# Altium schematic sheet ГРЛМ.468333.001 Э3.SchDoc
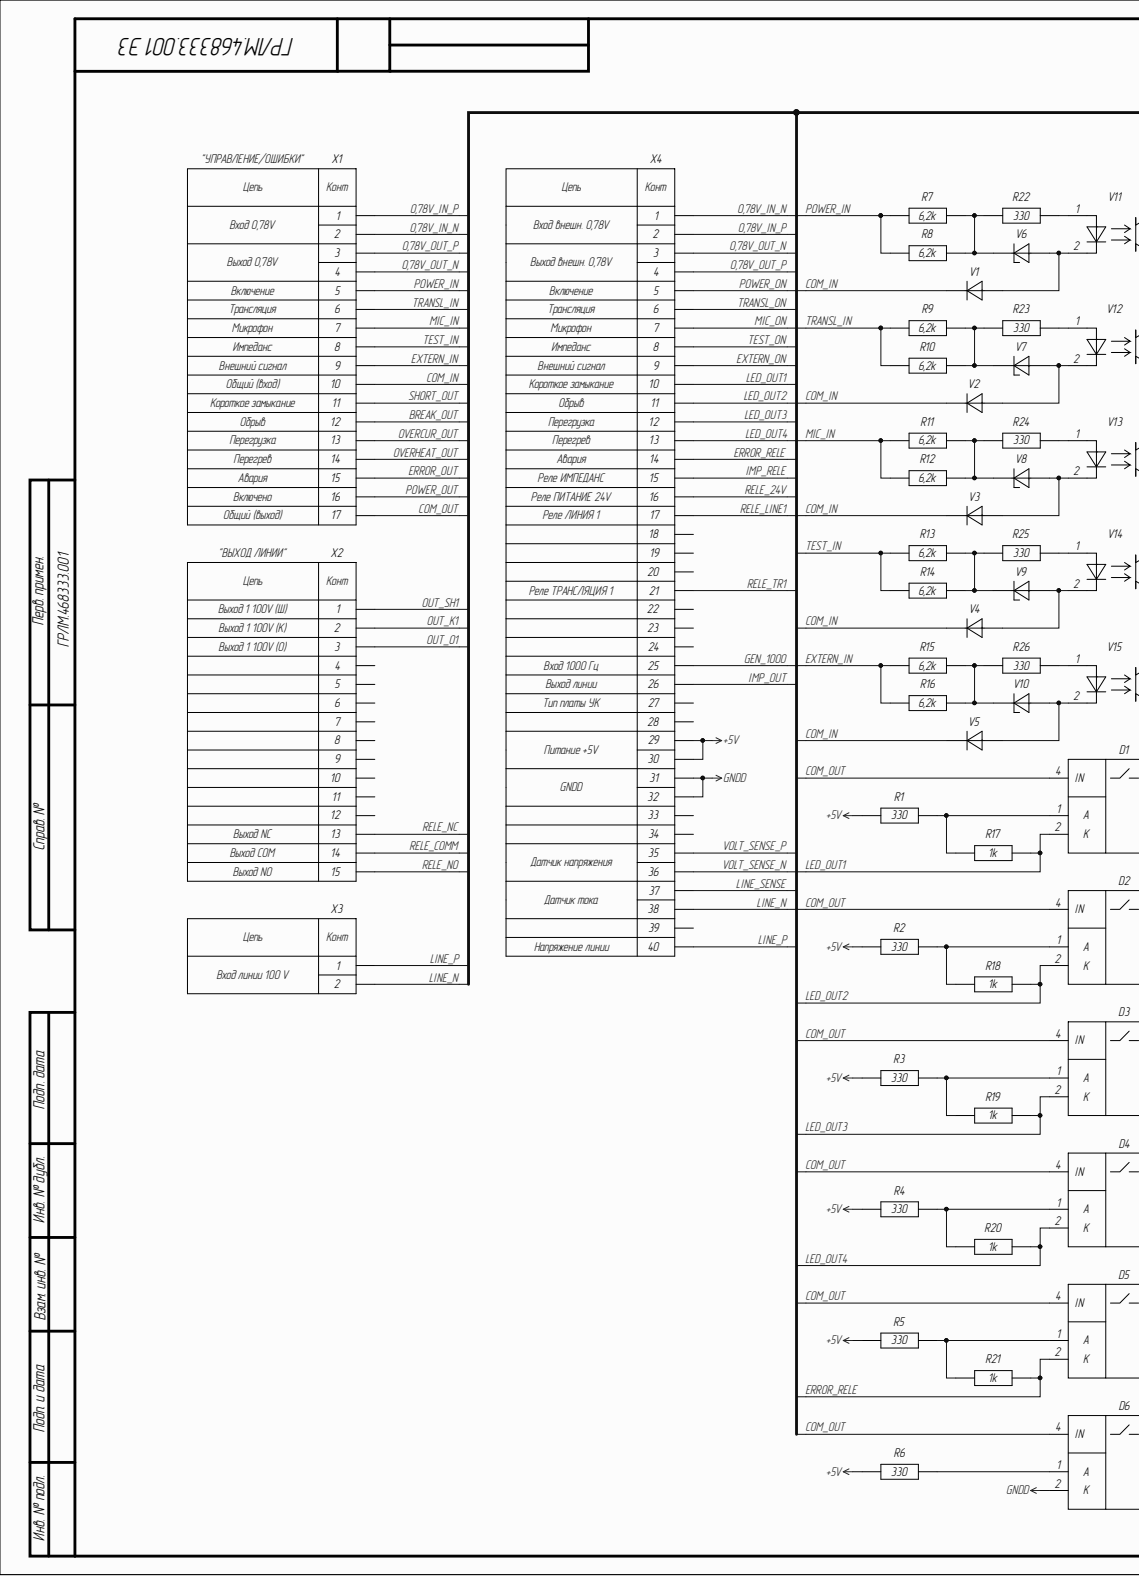
[diagram p0: ГРЛМ.468333.001 Э3.SchDoc, left]
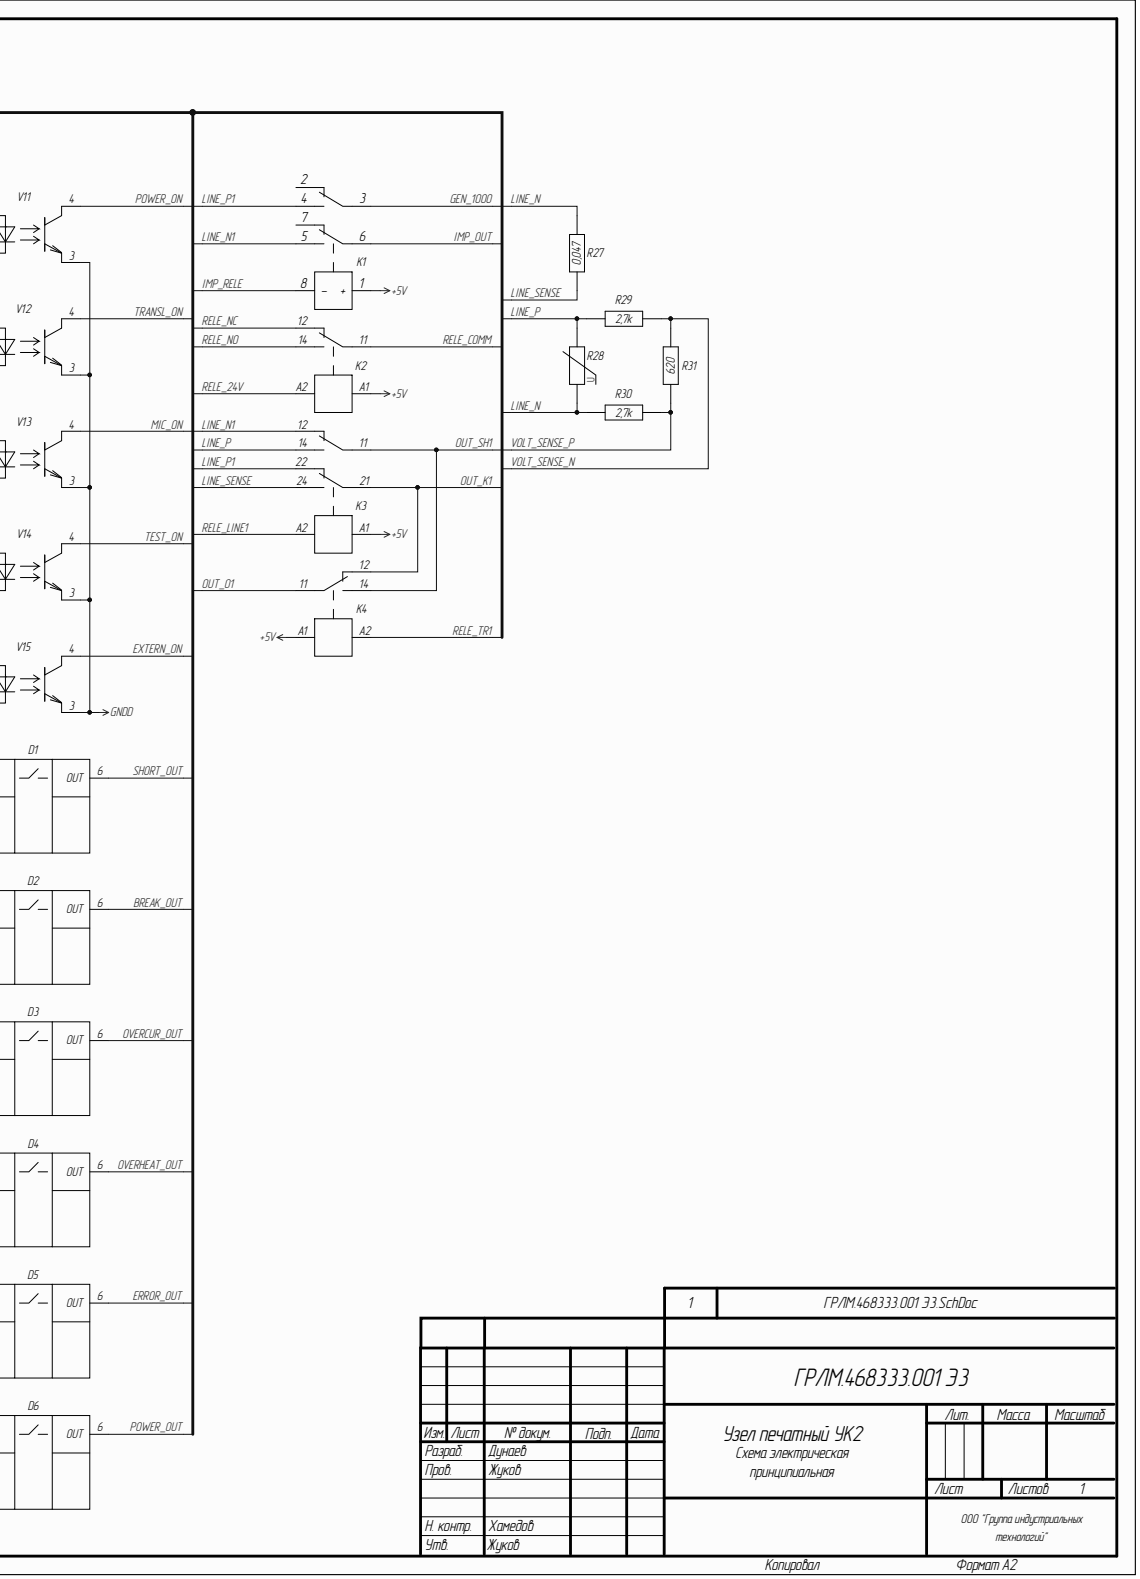
[diagram p1: ГРЛМ.468333.001 Э3.SchDoc, right]

## Parts
D1  LH1510AAB  [LH1510AAB]  (value not drawn)
D2  LH1510AAB  [LH1510AAB]  (value not drawn)
D3  LH1510AAB  [LH1510AAB]  (value not drawn)
D4  LH1510AAB  [LH1510AAB]  (value not drawn)
D5  LH1510AAB  [LH1510AAB]  (value not drawn)
D6  LH1510AAB  [LH1510AAB]  (value not drawn)
K1  IM03GR 1-1462037-4  [IM03GR]  (value not drawn)
K2  RY211005  [RY211005]  (value not drawn)
K3  RT424005  [RT424005]  (value not drawn)
K4  RY211005  [RY211005]  (value not drawn)
R1  value 330  [RES0805]  (hidden comment: RES_0805)
R2  value 330  [RES0805]  (hidden comment: RES_0805)
R3  value 330  [RES0805]  (hidden comment: RES_0805)
R4  value 330  [RES0805]  (hidden comment: RES_0805)
R5  value 330  [RES0805]  (hidden comment: RES_0805)
R6  value 330  [RES0805]  (hidden comment: RES_0805)
R7  value 6,2k  [RES2512]  (hidden comment: RES_2512)
R8  value 6,2k  [RES2512]  (hidden comment: RES_2512)
R9  value 6,2k  [RES2512]  (hidden comment: RES_2512)
R10  value 6,2k  [RES2512]  (hidden comment: RES_2512)
R11  value 6,2k  [RES2512]  (hidden comment: RES_2512)
R12  value 6,2k  [RES2512]  (hidden comment: RES_2512)
R13  value 6,2k  [RES2512]  (hidden comment: RES_2512)
R14  value 6,2k  [RES2512]  (hidden comment: RES_2512)
R15  value 6,2k  [RES2512]  (hidden comment: RES_2512)
R16  value 6,2k  [RES2512]  (hidden comment: RES_2512)
R17  value 1k  [RES0805]  (hidden comment: RES_0805)
R18  value 1k  [RES0805]  (hidden comment: RES_0805)
R19  value 1k  [RES0805]  (hidden comment: RES_0805)
R20  value 1k  [RES0805]  (hidden comment: RES_0805)
R21  value 1k  [RES0805]  (hidden comment: RES_0805)
R22  value 330  [RES0805]  (hidden comment: RES_0805)
R23  value 330  [RES0805]  (hidden comment: RES_0805)
R24  value 330  [RES0805]  (hidden comment: RES_0805)
R25  value 330  [RES0805]  (hidden comment: RES_0805)
R26  value 330  [RES0805]  (hidden comment: RES_0805)
R27  value 0,047  [RES2512]  (hidden comment: RES_2512)
R28  JVR-14N201K  [JVR-14N201K]  (value not drawn)
R29  value 2,7k  [RES1210]  (hidden comment: RES_1210)
R30  value 2,7k  [RES1210]  (hidden comment: RES_1210)
R31  value 620  [RES1210]  (hidden comment: RES_1210)
V1  LL4148  [LL4148]  (value not drawn)
V2  LL4148  [LL4148]  (value not drawn)
V3  LL4148  [LL4148]  (value not drawn)
V4  LL4148  [LL4148]  (value not drawn)
V5  LL4148  [LL4148]  (value not drawn)
V6  BZV55C4V7  [BZV55]  (value not drawn)
V7  BZV55C4V7  [BZV55]  (value not drawn)
V8  BZV55C4V7  [BZV55]  (value not drawn)
V9  BZV55C4V7  [BZV55]  (value not drawn)
V10  BZV55C4V7  [BZV55]  (value not drawn)
V11  HCPL-181-06AE  [HCPL-181]  (value not drawn)
V12  HCPL-181-06AE  [HCPL-181]  (value not drawn)
V13  HCPL-181-06AE  [HCPL-181]  (value not drawn)
V14  HCPL-181-06AE  [HCPL-181]  (value not drawn)
V15  HCPL-181-06AE  [HCPL-181]  (value not drawn)
X1  15EDGRC-3.81-17P-14  (value not drawn)
X2  2EDGRC-5.08-15P-14  (value not drawn)
X3  PWL2-02 (B2P-VH-B)  [PWL2-02]  (value not drawn)
X4  FDC-40  (value not drawn)

## Nets
+5V: K1.1(+) K2.A1 K3.A1 K4.A1 R1.1 R2.1 R3.1 R4.1 R5.1 R6.1 X4.29 X4.30
GNDD: D6.2(K) V11.3 V12.3 V13.3 V14.3 V15.3 X4.31 X4.32
0,78V_IN_N: X1.2 X4.1
0,78V_IN_P: X1.1 X4.2
0,78V_OUT_N: X1.4 X4.3
0,78V_OUT_P: X1.3 X4.4
BREAK_OUT: D2.6(OUT) X1.12
COM_IN: V1.1 V2.1 V3.1 V4.1 V5.1 X1.10
COM_OUT: D1.4(IN) D2.4(IN) D3.4(IN) D4.4(IN) D5.4(IN) D6.4(IN) X1.17
ERROR_OUT: D5.6(OUT) X1.15
ERROR_RELE: D5.2(K) R21.2 X4.14
EXTERN_IN: R15.1 R16.1 X1.9
EXTERN_ON: V15.4 X4.9
GEN_1000: K1.3 X4.25
IMP_OUT: K1.6 X4.26
IMP_RELE: K1.8(-) X4.15
LED_OUT1: D1.2(K) R17.2 X4.10
LED_OUT2: D2.2(K) R18.2 X4.11
LED_OUT3: D3.2(K) R19.2 X4.12
LED_OUT4: D4.2(K) R20.2 X4.13
LINE_N: R27.1 R28.1 R30.1 X3.2 X4.38
LINE_N1: K1.5 K3.12
LINE_P: K3.14 R28.2 R29.1 X3.1 X4.40
LINE_P1: K1.4 K3.22
LINE_SENSE: K3.24 R27.2 X4.37
MIC_IN: R11.1 R12.1 X1.7
MIC_ON: V13.4 X4.7
OUT_K1: K3.21 K4.12 X2.2
OUT_O1: K4.11 X2.3
OUT_SH1: K3.11 K4.14 X2.1
OVERCUR_OUT: D3.6(OUT) X1.13
OVERHEAT_OUT: D4.6(OUT) X1.14
POWER_IN: R7.1 R8.1 X1.5
POWER_ON: V11.4 X4.5
POWER_OUT: D6.6(OUT) X1.16
RELE_24V: K2.A2 X4.16
RELE_COMM: K2.11 X2.14
RELE_LINE1: K3.A2 X4.17
RELE_NC: K2.12 X2.13
RELE_NO: K2.14 X2.15
RELE_TR1: K4.A2 X4.21
SHORT_OUT: D1.6(OUT) X1.11
TEST_IN: R13.1 R14.1 X1.8
TEST_ON: V14.4 X4.8
TRANSL_IN: R9.1 R10.1 X1.6
TRANSL_ON: V12.4 X4.6
VOLT_SENSE_N: R29.2 R31.2 X4.36
VOLT_SENSE_P: R30.2 R31.1 X4.35
NetD1_1: D1.1(A) R1.2 R17.1
NetD2_1: D2.1(A) R2.2 R18.1
NetD3_1: D3.1(A) R3.2 R19.1
NetD4_1: D4.1(A) R4.2 R20.1
NetD5_1: D5.1(A) R5.2 R21.1
NetD6_1: D6.1(A) R6.2
NetR7_2: R7.2 R8.2 R22.1 V6.1
NetR9_2: R9.2 R10.2 R23.1 V7.1
NetR11_2: R11.2 R12.2 R24.1 V8.1
NetR13_2: R13.2 R14.2 R25.1 V9.1
NetR15_2: R15.2 R16.2 R26.1 V10.1
NetR22_2: R22.2 V11.1
NetR23_2: R23.2 V12.1
NetR24_2: R24.2 V13.1
NetR25_2: R25.2 V14.1
NetR26_2: R26.2 V15.1
NetV1_2: V1.2 V6.2 V11.2
NetV2_2: V2.2 V7.2 V12.2
NetV3_2: V3.2 V8.2 V13.2
NetV4_2: V4.2 V9.2 V14.2
NetV5_2: V5.2 V10.2 V15.2
N00028:

Not connected: K1 2 7; X2 4 5 6 7 8 9 10 11 12; X4 18 19 20 22 23 24 27 28 33 34 39

## Library data of the parts
D1-D6 = LH1510AAB: Оптореле; Производитель: Vishay; Component Kind: Standard; Library Name: Микросхемы.SchLib
K1 = IM03GR 1-1462037-4: Реле DC 5V; Производитель: TE Connectivity; Component Kind: Standard; Library Name: Реле.SchLib
K2 K4 = RY211005: Реле DC 5V 8A; Производитель: TE Connectivity; Component Kind: Standard; Library Name: Реле.SchLib
K3 = RT424005: Реле DC 5V 8A; Производитель: TE Connectivity; Component Kind: Standard; Library Name: Реле.SchLib
R1-R6 = RES_0805: Резистор SMD; Артикул: 0805-3,3 кОм±5%; Производитель: YAGEO; Component Kind: Standard; Type: RC0805JR-073K3L; Library Name: Резисторы.SchLib
R7-R16 = RES_2512: Резистор SMD; Артикул: 2512-6,2 кОм±5%; Производитель: YAGEO; Component Kind: Standard; Type: RC2512JK-076K2L; Library Name: Резисторы.SchLib
R17-R21 = RES_0805: Резистор SMD; Артикул: 0805-1 кОм±5%; Производитель: YAGEO; Component Kind: Standard; Type: RC0805JR-071KL; Library Name: Резисторы.SchLib
R22-R26 = RES_0805: Резистор SMD; Артикул: 0805-330 Ом±5%; Производитель: YAGEO; Component Kind: Standard; Type: RC0805JR-07330RL; Library Name: Резисторы.SchLib
R27 = RES_2512: Резистор SMD; Артикул: 2512-0,047 Ом±1%; Производитель: Tyco; Component Kind: Standard; Type: TLM3ADR047FTE; Library Name: Резисторы.SchLib
R28 = JVR-14N201K: Варистор 130 В 57 Дж; Производитель: Joyin; Component Kind: Standard; Library Name: Резисторы.SchLib
R29 R30 = RES_1210: Резистор SMD; Артикул: 1210-2,7 кОм±1%; Производитель: YAGEO; Component Kind: Standard; Type: RC1210FR-072K7L; Library Name: Резисторы.SchLib
R31 = RES_1210: Резистор SMD; Артикул: 1210-620 Ом±1%; Производитель: YAGEO; Component Kind: Standard; Type: RC1210FR-07620RL; Library Name: Резисторы.SchLib
V1-V5 = LL4148: Диод выпрямительный; Производитель: Vishay; Component Kind: Standard; Library Name: ГРЛМ.468363.001 Э3.SCHLIB
V6-V10 = BZV55C4V7: Стабилитрон; Производитель: NXP; Component Kind: Standard; Library Name: Полупроводники.SchLib
V11-V15 = HCPL-181-06AE: Оптопара; Производитель: Broadcom; Component Kind: Standard; Library Name: Микросхемы.SchLib
X1 = 15EDGRC-3.81-17P-14: Вилка; Component Kind: Standard; Library Name: Соединители.SchLib
X2 = 2EDGRC-5.08-15P-14: Вилка; Component Kind: Standard; Library Name: Соединители.SchLib
X3 = PWL2-02 (B2P-VH-B): Вилка; Component Kind: Standard; Library Name: Соединители.SchLib
X4 = FDC-40: Вилка; Component Kind: Standard; Library Name: Соединители.SchLib
